# Altium schematic sheet 05-UARTBridge.SchDoc
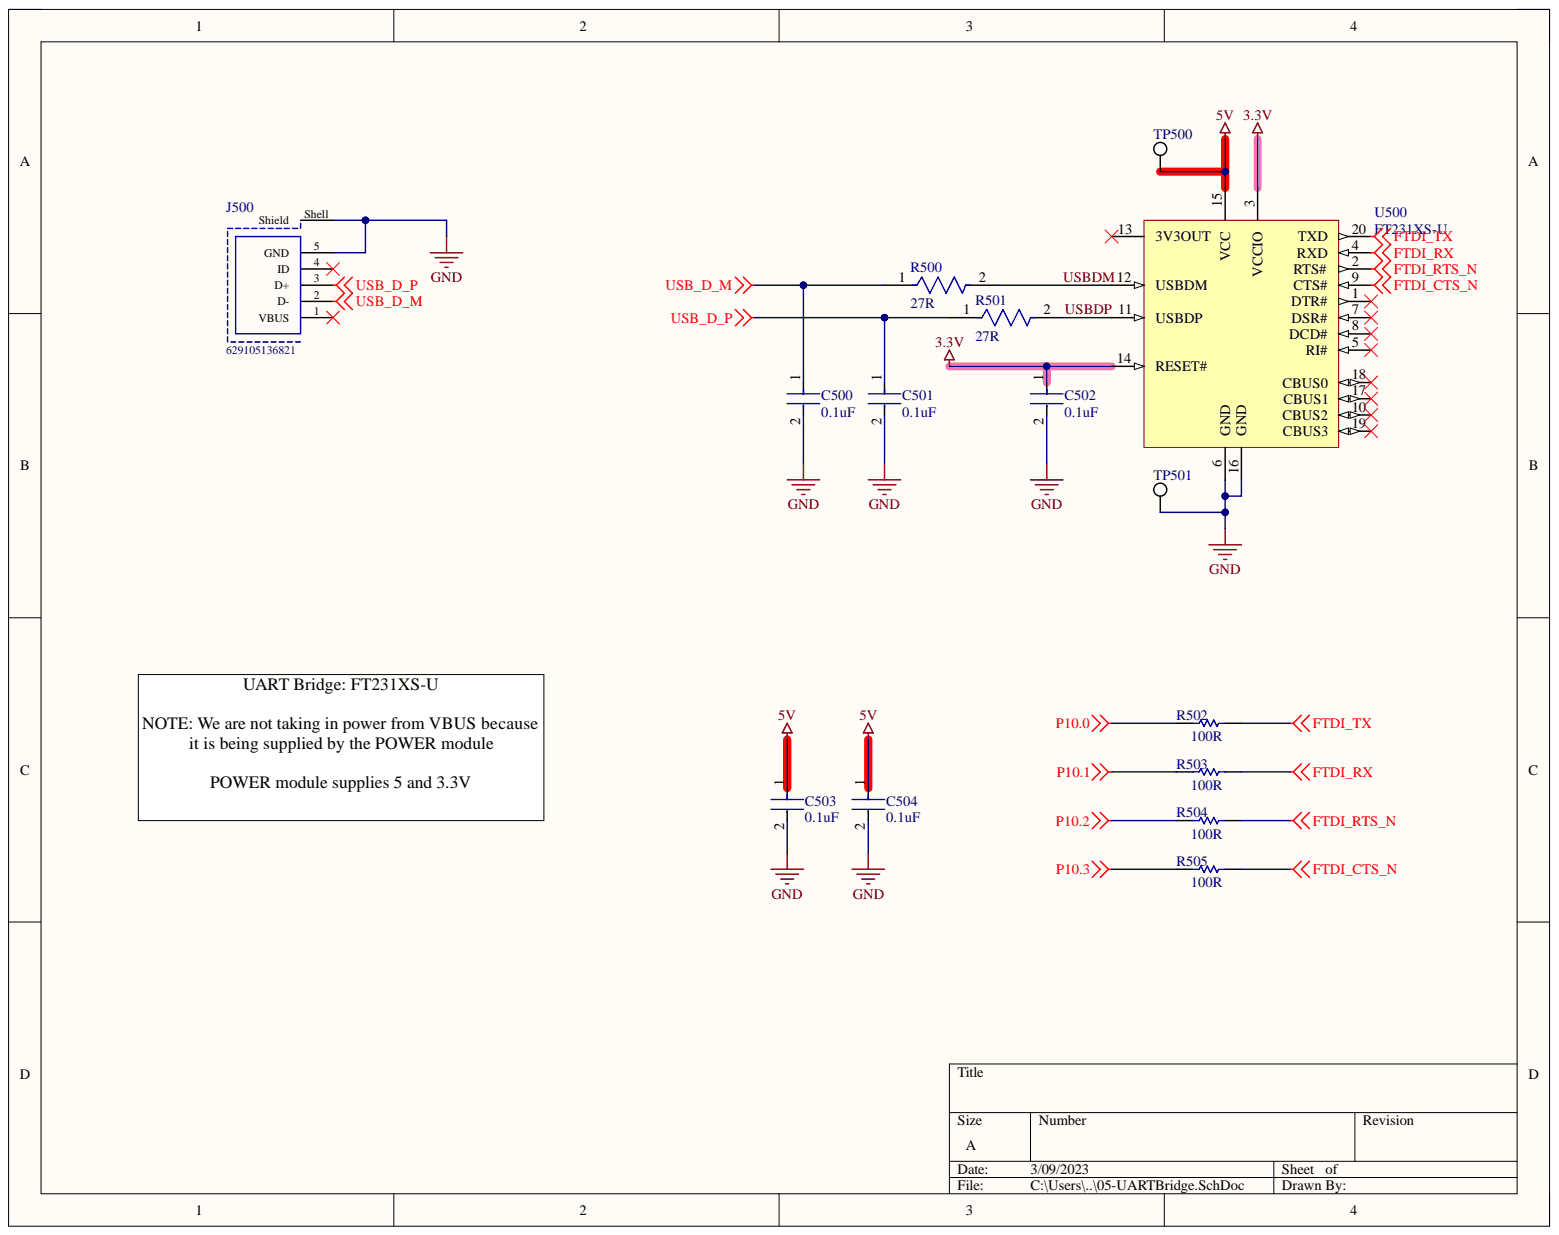
[diagram p0: 05-UARTBridge.SchDoc]

## Parts
C500  0.1uF  [FP-CL21-IPC_C]
C501  0.1uF  [FP-CL21-IPC_C]
C502  0.1uF  [FP-CL21-IPC_C]
C503  0.1uF  [FP-CL21-IPC_C]
C504  0.1uF  [FP-CL21-IPC_C]
J500  629105136821  [629105136821]
R500  27R  [FP-CRGCQ0805-IPC_C]
R501  27R  [FP-CRGCQ0805-IPC_C]
R502  100R  [RESC2013X60X40ML20T25]
R503  100R  [RESC2013X60X40ML20T25]
R504  100R  [RESC2013X60X40ML20T25]
R505  100R  [RESC2013X60X40ML20T25]
TP500  5019  [KSTN-5019_V]  (value not drawn)
TP501  5019  [KSTN-5019_V]  (value not drawn)
U500  FT231XS-U  [SSOP-20_L]

## Nets
3.3V: C502.1 U500.3(VCCIO) U500.14(RESET#)
5V: C503.1 C504.1 TP500.1 U500.15(VCC)
FTDI_CTS_N: R505.2 U500.9(CTS#)
FTDI_RTS_N: R504.2 U500.2(RTS#)
FTDI_RX: R503.2 U500.4(RXD)
FTDI_TX: R502.2 U500.20(TXD)
GND: C500.2 C501.2 C502.2 C503.2 C504.2 J500.5(GND) J500.Shell(Shield) TP501.1 U500.6(GND) U500.16(GND)
P10.0: R502.1
P10.1: R503.1
P10.2: R504.1
P10.3: R505.1
USB_D_M: C500.1 J500.2(D-) R500.1
USB_D_P: C501.1 J500.3(D+) R501.1
USBDM: R500.2 U500.12(USBDM)
USBDP: R501.2 U500.11(USBDP)

Not connected: J500 1(VBUS) 4(ID); U500 1(DTR#) 5(RI#) 7(DSR#) 8(DCD#) 10(CBUS2) 13(3V3OUT) 17(CBUS1) 18(CBUS0) 19(CBUS3)

## Library data of the parts
C500-C504 = CMP-2000-05017-2: CL21 Series 0805 100nF 50V ±10% Tolerance X7R Multilayer Ceramic Chip Capacitor; Capacitance: 100nF; Case Code (Imperial): 0805; Case Code (Metric): 2012; Case/Package: 0805; Component Kind: Standard; Contact Plating: Tin; Depth: 1.25mm; Dielectric: X7R; Height: 0.85mm; Lead Free: Lead Free; Length: 2mm; Library Name: Altium Content Vault; Material: Ceramic; Max Operating Temperature: 125°C; Min Operating Temperature: -55°C; Mount: Surface Mount; Packaging: Tape and Reel; Radiation Hardening: No; Thickness: 0.037inch; Tolerance: 10%; Voltage: 50V; Voltage Rating: 50V; Voltage Rating (DC): 50V; Width: 0.049inch
J500 = CMP-1502-03253-1: Micro USB 2.0 Type B Receptacle WR-COM, Horizontal, SMT, with Pads and Pegs; Manufacturer: Wurth Elektronik; Contact Resistance: 30mOhm; Rated Current: 1A; Working Voltage: 30VAC; Component Kind: Standard; Connector Type: MicroUSB2.0TypeB; Datasheet URL: https://katalog.we-online.de/em/datasheet/629105136821.pdf; Gender: Receptacle; Library Name: Altium Content Vault; Manufacturer URL: http://www.we-online.de; Mounting Technology: SMT; Operating Temperature: -40to105degC; Orientation: Horizontal; Package Reference: 629105136821; Packaging: TapeandReel; Part Number: 629105136821; Publisher: WurthElektronik
R500 R501 = CMP-03211-007904-1: CRGCQ0805 27Ω 0.125W ±200ppm/°C 1% 150V; Manufacturer: TE Connectivity; Manufacturer Part Number: CRGCQ0805F27R; Automotive Grade: Grade 323; Tolerance: 0.01; Ambient Temperature Range High: +70°C; Automotive: Yes; Category: Res; Component Kind: Standard; ComponentLink2Description: Datasheet; ComponentLink2URL: https://www.te.com/commerce/DocumentDelivery/DDEController?Action=showdoc&DocId=Data+Sheet%7F1773204-3%7F1%7Fpdf%7FEnglish%7FENG_DS_1773204-3_1.pdf%7F4-2176341-332; Composition: Thick Film; Device Class L1: Passive Components; Device Class L2: Resistors; Device Class L3: Chip SMD Resistors; Height: 0.65mm; Ipc Land Pattern Name: RESC200125X55; Lead Free: Yes; Library Name: Altium Content Vault; Mouser Description: Res General Purpose Thick Film 0805 27 Ohm 1% 1/8W ±200ppm/°C Molded Paper T/R; Octopart: https://octopart.com/crgcq0805f27r-te+connectivity-91018166; Package: CRGCQ0805; Power Rating: 0.125W; Resistance: 27Ω; RoHS: TRUE; Series: CRGCQ; Temperature: -55°C to +155°C; Temperature Coefficient: ±200ppm/°C; Temperature Range High: +155°C; Temperature Range Low: -55°C; Value: 27Ω; Voltage Rating: 150V
R502-R505 = CMP-2001-00580-1: Manufacturer: Panasonic; Part Number: ERA6AEB101V; Component Kind: Standard; Library Name: Altium Content Vault
TP500 TP501 = CMP-1672-00008-1: Test Point, 1 Position SMD, RoHS, Tape and Reel; Manufacturer 1: Keystone Electronics; Category: Connectors; Component Kind: Standard; ComponentLink1Description: Manufacturer URL; ComponentLink1URL: http://www.keyelco.com/; ComponentLink2Description: Datasheet; ComponentLink2URL: http://www.keyelco.com/product-pdf.cfm?p=1357; ComponentLink3Description: https://datasheet.ciiva.com/16318/m60-2p61-16318395.pdf?src-supplier=Future+Electronics; ComponentLink3URL: https://datasheet.ciiva.com/16318/m60-2p61-16318395.pdf?src-supplier=Future+Electronics; ComponentLink4Description: https://datasheet.ciiva.com/16885/70182998-16885945.pdf?src-supplier=Allied+Electronics; ComponentLink4URL: https://datasheet.ciiva.com/16885/70182998-16885945.pdf?src-supplier=Allied+Electronics; ComponentLink5Description: https://datasheet.ciiva.com/14848/m60-2p61-14848437.pdf?src-supplier=Digi-Key; ComponentLink5URL: https://datasheet.ciiva.com/14848/m60-2p61-14848437.pdf?src-supplier=Digi-Key; ComponentLink6Description: https://datasheet.ciiva.com/14848/m60-2p61-14848438.pdf?src-supplier=Digi-Key; ComponentLink6URL: https://datasheet.ciiva.com/14848/m60-2p61-14848438.pdf?src-supplier=Digi-Key; ComponentLink7Description: https://datasheet.ciiva.com/14848/m60-2p61-14848439.pdf?src-supplier=Digi-Key; ComponentLink7URL: https://datasheet.ciiva.com/14848/m60-2p61-14848439.pdf?src-supplier=Digi-Key; Contact Material: Bronze; Contact Plating: Silver; DatasheetVersion: 03/2014; Height: 0.09inch; Lead Free: Lead Free; Library Name: Altium Content Vault; Manufacturer Part Number 1: 5019; Material: Bronze; Mount: Surface Mount; Mounting Technology: Surface Mount; Number of Pins: 1; Package Quantity: 1000; PackageDescription: 1-Pin Surface Mount Device, Body 3.81 x 2.03 mm; PackageReference: 5019; Packaging: Tape and Reel; Pins: 1; Pricing 1: 1=0.34000, 25=0.27360, 100=0.22340, 250=0.20064, 500=0.19152 (USD); REACH SVHC: No SVHC; RoHS: Yes; RoHS Compliant: Yes; RoHSCompliant: True; Series: 5019; Stock 1: 1316816; Supplier 1: Digi-Key; Supplier Part Number 1: 36-5019CT-ND; Termination: SMD/SMT
U500 = CMP-0248-00015-2: USB to Full-Handshake UART Interface Chip, UHCI/OHCI/EHCI Compatible, USB 2.0 Compatible, -40 to +85 degC, 20-Pin SSOP, Pb-Free, Tube; Manufacturer: FTDI; Code_JEDEC: MO-137-AD; Component Kind: Standard; ComponentLink1Description: Manufacturer URL; ComponentLink1URL: http://www.ftdichip.com/; ComponentLink2Description: Datasheet; ComponentLink2URL: http://www.ftdichip.com/Support/Documents/DataSheets/ICs/DS_FT231X.pdf; ComponentLink3Description: FT231X Product Page; ComponentLink3URL: www.ftdichip.com/Products/ICs/FT231X.html; DatasheetVersion: ver 1.2, Feb-2013; Library Name: Altium Content Vault; PackageDescription: 20-Pin Small Outline Package, 8.6 x 3.9 mm Body, 0.635 mm Pitch; PackageReference: SSOP-20; PackageVersion: Feb-13; PartNumber: FT231XS-U; RevisionNote: DatasheetVersion updated to 1.2 Feb-2013
